# Altium schematic sheet CN032-1 Industry EtherCAT Servo Controller_MCU3.SchDoc
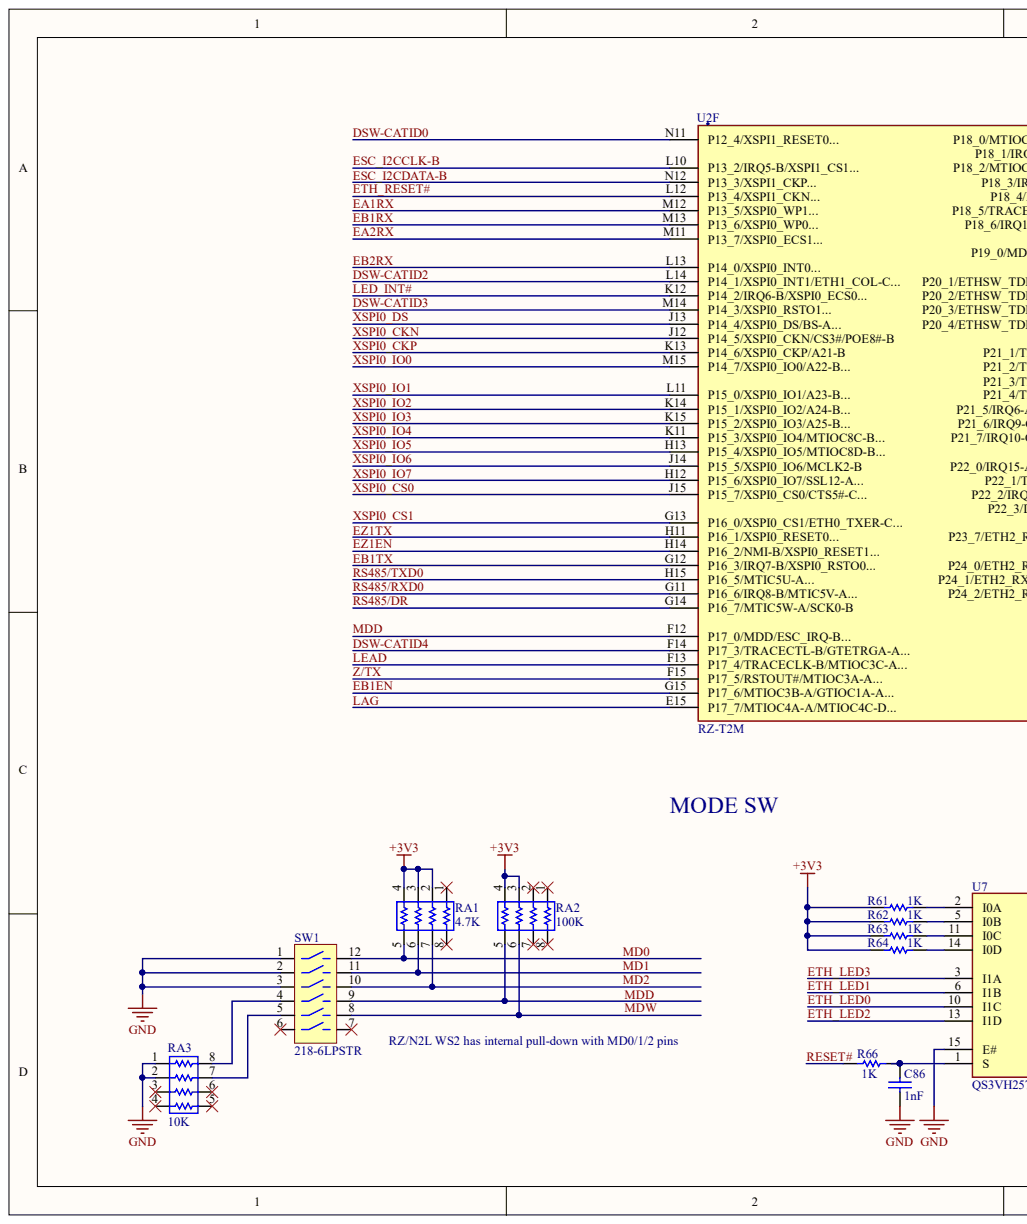
[diagram p0: CN032-1 Industry EtherCAT Servo Controller_MCU3.SchDoc, left]
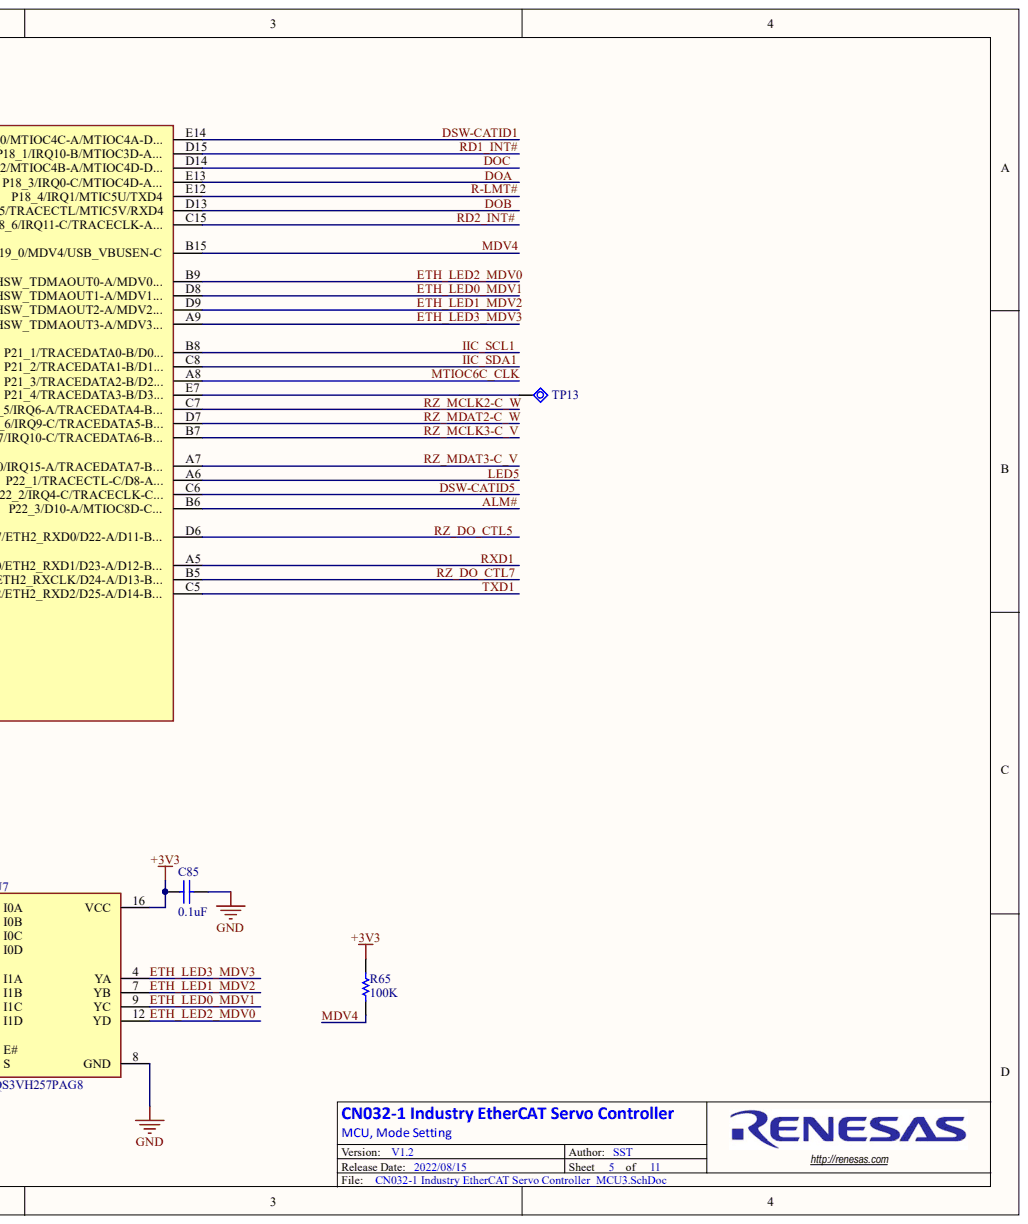
[diagram p1: CN032-1 Industry EtherCAT Servo Controller_MCU3.SchDoc, right]

## Parts
C85  0.1uF  [CAPC0402-01]
C86  1nF  [CAPC0402-01]
R61  1K  [RESC0402-01]
R62  1K  [RESC0402-01]
R63  1K  [RESC0402-01]
R64  1K  [RESC0402-01]
R65  100K  [RESC0402-01]
R66  1K  [RESC0402-01]
RA1  4.7K  [RESCAF0804]
RA2  100K  [RESCAF0804]
RA3  10K  [RESCAF0804]
SW1  218-6LPSTR  [SW_CTS_218-6LPST]
TP13  Test Point  [TEST POINT]  (value not drawn)
U2  RZ-T2M  [RZ-N2L_BGA225]  (part F)
U7  QS3VH257PAG8  [QS3VH257PAG8]

## Nets
+3V3: C85.2 R61.2 R62.2 R63.2 R64.2 R65.2 RA1.2 RA1.3 RA1.4 RA2.3 RA2.4 U7.16(VCC)
GND: C85.1 C86.1 RA3.1 RA3.2 SW1.1 SW1.2 SW1.3 U7.8(GND) U7.15(E#)
ALM#: U2.B6(P22_3/D10-A/MTIOC8D-C...)
DOA: U2.E13(P18_3/IRQ0-C/MTIOC4D-A...)
DOB: U2.D13(P18_5/TRACECTL/MTIC5V/RXD4)
DOC: U2.D14(P18_2/MTIOC4B-A/MTIOC4D-D...)
DSW-CATID0: U2.N11(P12_4/XSPI1_RESET0...)
DSW-CATID1: U2.E14(P18_0/MTIOC4C-A/MTIOC4A-D...)
DSW-CATID2: U2.L14(P14_1/XSPI0_INT1/ETH1_COL-C...)
DSW-CATID3: U2.M14(P14_3/XSPI0_RSTO1...)
DSW-CATID4: U2.F14(P17_3/TRACECTL-B/GTETRGA-A...)
DSW-CATID5: U2.C6(P22_2/IRQ4-C/TRACECLK-C...)
EA1RX: U2.M12(P13_5/XSPI0_WP1...)
EA2RX: U2.M11(P13_7/XSPI0_ECS1...)
EB1EN: U2.G15(P17_6/MTIOC3B-A/GTIOC1A-A...)
EB1RX: U2.M13(P13_6/XSPI0_WP0...)
EB1TX: U2.G12(P16_3/IRQ7-B/XSPI0_RSTO0...)
EB2RX: U2.L13(P14_0/XSPI0_INT0...)
ESC_I2CCLK-B: U2.L10(P13_2/IRQ5-B/XSPI1_CS1...)
ESC_I2CDATA-B: U2.N12(P13_3/XSPI1_CKP...)
ETH_LED0: U7.10(I1C)
ETH_LED0_MDV1: U2.D8(P20_2/ETHSW_TDMAOUT1-A/MDV1...) U7.9(YC)
ETH_LED1: U7.6(I1B)
ETH_LED1_MDV2: U2.D9(P20_3/ETHSW_TDMAOUT2-A/MDV2...) U7.7(YB)
ETH_LED2: U7.13(I1D)
ETH_LED2_MDV0: U2.B9(P20_1/ETHSW_TDMAOUT0-A/MDV0...) U7.12(YD)
ETH_LED3: U7.3(I1A)
ETH_LED3_MDV3: U2.A9(P20_4/ETHSW_TDMAOUT3-A/MDV3...) U7.4(YA)
ETH_RESET#: U2.L12(P13_4/XSPI1_CKN...)
EZ1EN: U2.H14(P16_2/NMI-B/XSPI0_RESET1...)
EZ1TX: U2.H11(P16_1/XSPI0_RESET0...)
IIC_SCL1: U2.B8(P21_1/TRACEDATA0-B/D0...)
IIC_SDA1: U2.C8(P21_2/TRACEDATA1-B/D1...)
LAG: U2.E15(P17_7/MTIOC4A-A/MTIOC4C-D...)
LEAD: U2.F13(P17_4/TRACECLK-B/MTIOC3C-A...)
LED5: U2.A6(P22_1/TRACECTL-C/D8-A...)
LED_INT#: U2.K12(P14_2/IRQ6-B/XSPI0_ECS0...)
MD0: RA1.5 SW1.12
MD1: RA1.6 SW1.11
MD2: RA1.7 SW1.10
MDD: RA2.5 SW1.9 U2.F12(P17_0/MDD/ESC_IRQ-B...)
MDV4: R65.1 U2.B15(P19_0/MDV4/USB_VBUSEN-C)
MDW: RA2.6 SW1.8
MTIOC6C_CLK: U2.A8(P21_3/TRACEDATA2-B/D2...)
R-LMT#: U2.E12(P18_4/IRQ1/MTIC5U/TXD4)
RD1_INT#: U2.D15(P18_1/IRQ10-B/MTIOC3D-A...)
RD2_INT#: U2.C15(P18_6/IRQ11-C/TRACECLK-A...)
RESET#: R66.2
RS485/DR: U2.G14(P16_7/MTIC5W-A/SCK0-B)
RS485/RXD0: U2.G11(P16_6/IRQ8-B/MTIC5V-A...)
RS485/TXD0: U2.H15(P16_5/MTIC5U-A...)
RXD1: U2.A5(P24_0/ETH2_RXD1/D23-A/D12-B...)
RZ_DO_CTL5: U2.D6(P23_7/ETH2_RXD0/D22-A/D11-B...)
RZ_DO_CTL7: U2.B5(P24_1/ETH2_RXCLK/D24-A/D13-B...)
RZ_MCLK2-C_W: U2.C7(P21_5/IRQ6-A/TRACEDATA4-B...)
RZ_MCLK3-C_V: U2.B7(P21_7/IRQ10-C/TRACEDATA6-B...)
RZ_MDAT2-C_W: U2.D7(P21_6/IRQ9-C/TRACEDATA5-B...)
RZ_MDAT3-C_V: U2.A7(P22_0/IRQ15-A/TRACEDATA7-B...)
TXD1: U2.C5(P24_2/ETH2_RXD2/D25-A/D14-B...)
XSPI0_CKN: U2.J12(P14_5/XSPI0_CKN/CS3#/POE8#-B)
XSPI0_CKP: U2.K13(P14_6/XSPI0_CKP/A21-B)
XSPI0_CS0: U2.J15(P15_7/XSPI0_CS0/CTS5#-C...)
XSPI0_CS1: U2.G13(P16_0/XSPI0_CS1/ETH0_TXER-C...)
XSPI0_DS: U2.J13(P14_4/XSPI0_DS/BS-A...)
XSPI0_IO0: U2.M15(P14_7/XSPI0_IO0/A22-B...)
XSPI0_IO1: U2.L11(P15_0/XSPI0_IO1/A23-B...)
XSPI0_IO2: U2.K14(P15_1/XSPI0_IO2/A24-B...)
XSPI0_IO3: U2.K15(P15_2/XSPI0_IO3/A25-B...)
XSPI0_IO4: U2.K11(P15_3/XSPI0_IO4/MTIOC8C-B...)
XSPI0_IO5: U2.H13(P15_4/XSPI0_IO5/MTIOC8D-B...)
XSPI0_IO6: U2.J14(P15_5/XSPI0_IO6/MCLK2-B)
XSPI0_IO7: U2.H12(P15_6/XSPI0_IO7/SSL12-A...)
Z/TX: U2.F15(P17_5/RSTOUT#/MTIOC3A-A...)
NetC86_2: C86.2 R66.1 U7.1(S)
NetR61_1: R61.1 U7.2(I0A)
NetR62_1: R62.1 U7.5(I0B)
NetR63_1: R63.1 U7.11(I0C)
NetR64_1: R64.1 U7.14(I0D)
NetRA3_7: RA3.7 SW1.5
NetRA3_8: RA3.8 SW1.4
NetTP13_1: TP13.1 U2.E7(P21_4/TRACEDATA3-B/D3...)

Not connected: RA1 1 8; RA2 1 2 7 8; RA3 3 4 5 6; SW1 6 7

## Library data of the parts
C85 = CAP-0402-0.1uF-YAGEO: Ceramic Chip Capacitor 0402 0.1uF 16V; Manufacturer: YAGEO; Mfg Part Number: CC0402KPX7R7BB104; Dialectric: X7R; Tolerance: 10%; Capacitance: 0.1uF; Component Kind: Standard; Package: 0402; Voltage: 16V; Library Name: Synergy.SchLib
C86 = CAP-0402-1nF: Ceramic Chip Capacitor 0402 1nF 16V; Manufacturer: YAGEO; Mfg Part Number: CC0402KRX7R7BB102; Dialectric: X7R; Tolerance: ±10%; Capacitance: 1nF; Component Kind: Standard; Package: 0402; Voltage: 16V; Library Name: Synergy.IntLib
R61-R64 R66 = RES-0402-1K: Chip Resistor Thick Film 0402 1K 1% 1/16W; Manufacturer: YAGEO; Mfg Part Number: RC0402FR-071KL; Component Kind: Standard; Package: 0402; Power: 1/16W; Resistance: 1K; Tolerance: 1%; Library Name: Synergy.SchLib
R65 = RES-0402-100K: Chip Resistor Thick Film 0402 100K 1% 1/16W; Manufacturer: YAGEO; Mfg Part Number: RC0402FR-07100KL; Component Kind: Standard; Package: 0402; Power: 1/16W; Resistance: 100K; Tolerance: 1%; Library Name: Synergy.SchLib
RA1 = RES-0804-4.7K_1: Chip Resistor Array 0804 4.7K 5% 1/16W 4 x 0402; Manufacturer: YAGEO; Mfg Part Number: YC124-JR-074K7L; Component Kind: Standard; Package: 0804; Power: 1/16W; Resistance: 4.7K; Tolerance: 5%; Library Name: Synergy.IntLib
RA2 = RES-0804-100K_1: Chip Resistor Array 0804 100K 5% 1/16W 4 x 0402; Manufacturer: YAGEO; Mfg Part Number: YC124-JR-07100KL; Component Kind: Standard; Package: 0804; Power: 1/16W; Resistance: 100K; Tolerance: 5%; Library Name: CN268 IEEE-1588.SchLib
RA3 = RES-0804-10K_1: Chip Resistor Array 0804 10K 5% 1/16W 4 x 0402; Manufacturer: YAGEO; Mfg Part Number: YC124-JR-0710KL; Component Kind: Standard; Package: 0804; Power: 1/16W; Resistance: 10K; Tolerance: 5%; Library Name: CN268 IEEE-1588.SchLib
SW1 = PSW_218-6LPSTR: SW 6P SPST DIP 25mA 24V SMT; Manufacturer: CTS; Component Kind: Standard; Mfg Part Number: 218-6LPSTR; Part Type: DIP Switch; Value: 218-6LPSTR; Library Name: CN268 IEEE-1588.SchLib
TP13 = CON-Test Point: Component Kind: Standard; Description: Test Point; Library Name: Synergy.SchLib
U2 = MCU-RZ-N2L: Renesas RZ-T2M MCU; Component Kind: Standard; Display Name: RZ-T2M; Manufacturer: Renesas; Library Name: Synergy.SchLib
U7 = ICN-QS3VH257PAG8: IC BUS SWITCH 4 X 2:1 16TSSOP; Manufacturer: Renesas; Component Kind: Standard; Display Name: QS3VH257PAG8; Mfg Part Number: QS3VH257PAG8; Package: 16-TSSOP（0.173"，4.40mm 宽）; Library Name: Synergy.SchLib
